# Altium schematic sheet Sheet2.SchDoc
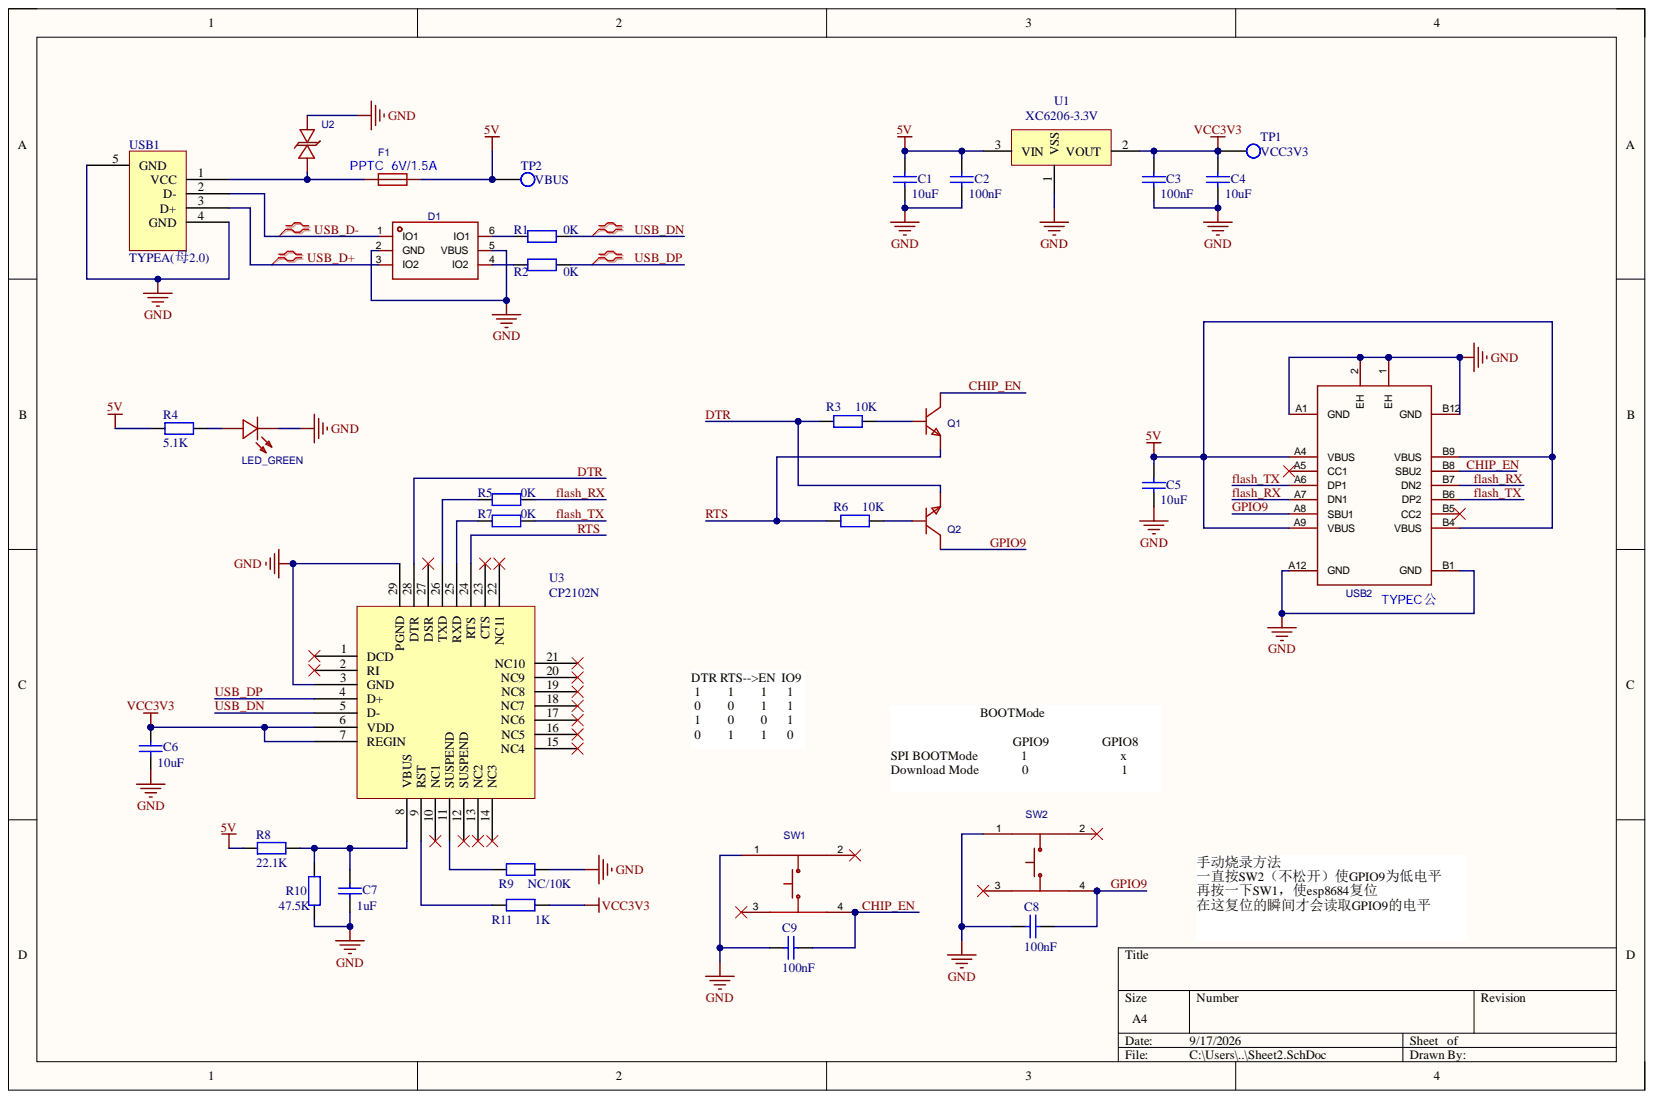
[diagram p0: Sheet2.SchDoc]

## Parts
C1  10uF  [0603_C]
C2  100nF  [0603_C]
C3  100nF  [0603_C]
C4  10uF  [0603_C]
C5  10uF  [0603_C]
C6  10uF  [0603_C]
C7  1uF  [0603_C]
C8  100nF  [0603_C]
C9  100nF  [0603_C]
D1  usblc6-2sc6_c2827654  [SOT-23-6（TVS)]  (value not drawn)
F1  PPTC   6V/1.5A  [0805（PPTC)]
LED_GREEN  ltst-c190kskt  [0805(绿灯）]  (value not drawn)
Q1  l8050qlt1g  [SOT-23(BJT)]  (value not drawn)
Q2  l8050qlt1g  [SOT-23(BJT)]  (value not drawn)
R1  0K  [R0603_L]
R2  0K  [R0603_L]
R3  10K  [R0603_L]
R4  5.1K  [R0603_L]
R5  0K  [R0603_L]
R6  10K  [R0603_L]
R7  0K  [R0603_L]
R8  22.1K  [R0603_L]
R9  NC/10K  [R0603_L]
R10  47.5K  [R0603_L]
R11  1K  [R0603_L]
SW1  ts5215a 250gf  [SMD-4P,5.2x5.2mm(按键）]  (value not drawn)
SW2  ts5215a 250gf  [SMD-4P,5.2x5.2mm(按键）]  (value not drawn)
TP1  VCC3V3  [TEST 1.2]
TP2  VBUS  [TEST 1.2]
U1  XC6206-3.3V  [SOT-23-3L(LDO)]
U2  bnlesd5d5.0ct1g  [SOD-523(TVS)]  (value not drawn)
U3  CP2102N  [QFN-28-EP(5x5)(USB转UART)]
USB1  TYPEA(母2.0)  [TYPEA(母2.0)]
USB2  TYPEC公  Gender: 公  [TYPEC公]

## Nets
5V: C1.2 C2.2 C5.2 F1.2 R4.2 R8.2 TP2.1 U1.3 USB2.A4(VBUS) USB2.A9(VBUS) USB2.B4(VBUS) USB2.B9(VBUS)
GND: C1.1 C2.1 C3.1 C4.1 C5.1 C6.1 C7.1 C8.2 C9.2 D1.2(GND) D1.5(VBUS) LED_GREEN.1 R9.1 R10.2 SW1.1 SW2.1 U1.1 U2.2 U3.3(GND) U3.29(PGND) USB1.4(GND) USB1.5(GND) USB2.1(EH) USB2.2(EH) USB2.A1(GND) USB2.A12(GND) USB2.B1(GND) USB2.B12(GND)
VCC3V3: C3.2 C4.2 C6.2 R11.1 TP1.1 U1.2 U3.6(VDD) U3.7(REGIN)
CHIP_EN: C9.1 Q1.3 SW1.4 USB2.B8(SBU2)
DTR: Q2.2 R3.1 U3.28(DTR)
flash_RX: R5.1 USB2.A7(DN1) USB2.B7(DN2)
flash_TX: R7.1 USB2.A6(DP1) USB2.B6(DP2)
GPIO9: C8.1 Q2.3 SW2.4 USB2.A8(SBU1)
RTS: Q1.2 R6.1 U3.24(RTS)
USB_D+: D1.3(IO2) USB1.3(D+)
USB_D-: D1.1(IO1) USB1.2(D-)
USB_DN: R1.2 U3.5(D-)
USB_DP: R2.2 U3.4(D+)
NetC7_2: C7.2 R8.1 R10.1 U3.8(VBUS)
NetD1_4: D1.4(IO2) R2.1
NetD1_6: D1.6(IO1) R1.1
NetF1_1: F1.1 U2.1 USB1.1(VCC)
NetLED_GREEN_2: LED_GREEN.2 R4.1
NetQ1_1: Q1.1 R3.2
NetQ2_1: Q2.1 R6.2
NetR5_2: R5.2 U3.26(TXD)
NetR7_2: R7.2 U3.25(RXD)
NetR9_2: R9.2 U3.11(SUSPEND)
NetR11_2: R11.2 U3.9(RST)

Not connected: SW1 2 3; SW2 2 3; U3 1(DCD) 2(RI) 10(NC1) 12(SUSPEND) 13(NC2) 14(NC3) 15(NC4) 16(NC5) 17(NC6) 18(NC7) 19(NC8) 20(NC9) 21(NC10) 22(NC11) 23(CTS) 27(DSR); USB2 A5(CC1) B5(CC2)

## Library data of the parts
C1-C9 = 0603 22uF (226) 20% 6.3V: 贴片电容; Component Kind: Standard; ComponentLink1Description: 供应商链接; ComponentLink1URL: http://www.szlcsc.com/product/details_60514.html; Library Name: Miscellaneous Devices LC.IntLib; Package: 0603; Supplier: LC; SuppliersPartNumber: C59461
D1 = usblc6-2sc6_c2827654: 极性:单向;反向截止电压(Vrwm):5V;钳位电压:12V;峰值脉冲电流(Ipp):6A;峰值脉冲功率(Ppp):150W;击穿电压(VBR):6V;; Manufacturer: TECH PUBLIC(台舟); AddInBom: yes; Clamping Voltage: 12V; Junction Capacitance: 0.35pF; level of protection: IEC 61000-4-2；IEC 61000-4-4；IEC 61000-4-5; ModelId: 18da8f6c14a34920b3e136b5c401a119; ModelName: SOT-23-6_L2.9-W1.6-H1.5-LS2.8-P0.95; ModelTransform: 110.236,113.364,0,90,0,0,0.0005,0.0005,0; Number of Channels: 双路; Peak Pulse Current (Ipp): 6A; Peak Pulse Power Dissipation (Ppp): 150W; Polarity: 单向; Reverse Leakage Current (Ir): 80nA; Reverse Stand-Off Voltage (Vrwm): 5V; Type: ESD; Voltage - Breakdown: 6V; Component Kind: Standard; ConvertToPcb: yes; Datasheet: https://item.szlcsc.com/datasheet/USBLC6-2SC6/2973336.html; FootprintName: SOT-23-6_L2.9-W1.6-P0.95-LS2.8-BL; JLCPCB Part Class: Extended Part; LCSC Part Name: 单向ESD 5V截止 峰值脉冲电流：6A; ManufacturerPart: USBLC6-2SC6; Operating Temperature: -55℃~+125℃; Supplier: LCSC; Supplier Footprint: SOT-23-6; SupplierPart: C2827654; Symbol: USBLC6-2SC6_C2827654
F1 = smd0805-150-6: 耐压(Vmax):6V;最大电流(Imax):40A;保持电流(Ihold):1.5A;跳闸电流(Itrip):3A;; Manufacturer: R+O(宏嘉诚); AddInBom: yes; Current - Max: 40A; Hold Current: 1.5A; ModelId: 57e2aa106f9143e8b6ab832aebb31c78; ModelName: F0805_L2.1-W1.4-H0.8; ModelTransform: 82.677,55.118,0,0,0,0,0.0005,0,0; Power Dissipation: 1W; Resistance - Initial (Ri) (Min): 15mΩ; Resistance - Post Trip (R1) (Max): 130mΩ; Time to Trip(Max): 500ms; Trip Current: 3A; Voltage - Max: 6V; Component Kind: Standard; ConvertToPcb: yes; Datasheet: https://item.szlcsc.com/datasheet/SMD0805-150-6/51271788.html; FootprintName: F0805; Height: 1.5mm; JLCPCB Part Class: Extended Part; LCSC Part Name: 6V 1.5A; Length: 2.2mm; ManufacturerPart: SMD0805-150-6; Operating Temperature: -40℃~+85℃; Pitch: -; Supplier: LCSC; Supplier Footprint: 0805; SupplierPart: C48951237; Symbol: SMD0805-150-6; Width: 1.5mm
LED_GREEN = ltst-c190kskt: 绿灯; Manufacturer: LITEON(光宝); AddInBom: yes; Diode Configuration: 独立二极管; Forward Current: 20mA; Illumination Color: 黄色; Lens Color: 无色透明透镜; Luminous Intensity: 104mcd; ModelId: 1f0d29a91be547639d425604e9b96ebb; ModelName: LED0603-RD; ModelTransform: 63.7794,32.283,0,0,0,0,0,0,0; Peak Wavelength: 588nm; Power(Watts): 75mW; Viewing Angle: 130°; Voltage - Forward(Vf): 2.1V; Wavelength - Dominant: 584.5nm~597nm; Color Temperature: -; Component Kind: Standard; ConvertToPcb: yes; Datasheet: https://item.szlcsc.com/datasheet/LTST-C190KSKT/136371.html; FootprintName: LED0603-RD; JLCPCB Part Class: Extended Part; Lamp Holder Type: -; LCSC Part Name: 黄灯; ManufacturerPart: LTST-C190KSKT; Mounting Style: -; Operating Temperature: -30℃~+85℃; Supplier: LCSC; Supplier Footprint: 0603; SupplierPart: C125095; Symbol: LTST-C190KSKT
Q1 Q2 = l8050qlt1g: 晶体管类型:NPN;集电极电流(Ic):800mA;集射极击穿电压(Vceo):25V;耗散功率(Pd):225mW;直流电流增益(hFE):100;; Manufacturer: LRC(乐山无线电); AddInBom: yes; Collector - Emitter Voltage VCEO: 25V; Current - Collector Cutoff: 150nA; Current - Collector(Ic): 800mA; DC Current Gain: 100; Emitter-Base Voltage(Vebo): 5V; ModelId: c62791f0466c4ae2a892c056b845b0ca; ModelName: SOT-23-3P_L2.9-W1.3-H1.0-LS2.4-P0.95; ModelTransform: 98.425,114.173,0,180,0,0,0.0005,-0.0005,0; Number: 1个NPN; Pd - Power Dissipation: 225mW; type: NPN; Vce Saturation(VCE(sat)): 500mV; Component Kind: Standard; ConvertToPcb: yes; Datasheet: https://item.szlcsc.com/datasheet/L8050QLT1G/50589.html; FootprintName: SOT-23-3_L2.9-W1.3-P1.90-LS2.4-BR; JLCPCB Part Class: Extended Part; LCSC Part Name: NPN 电流:800mA 电压:25V; ManufacturerPart: L8050QLT1G; Operating Temperature: -55℃~+150℃; Supplier: LCSC; Supplier Footprint: SOT-23; SupplierPart: C49581; Symbol: L8050QLT1G
R1-R11 = R: 贴片电阻; 联系下单店铺卖家 免费帮你查找封装: 联系下单店铺卖家 免费技术支持; BOM名称: 贴片电阻; Component Kind: Standard; Library Name: 0.1 - 电阻-电容-电感-保险丝-晶振-晶体-磁珠-电位器-法拉电容-馈通电容.SchLib
SW1 SW2 = ts5215a 250gf: 电路结构:单刀单掷;按钮形状:圆形按钮;作用力:2.5N;安装方式:立贴;开关长度:5.2mm;开关宽度:5.2mm;开关高度:1.5mm;带支架:不带支架;触点电流:50mA;额定电压:12V;带灯:无;工作寿命:10万次;; Manufacturer: SHOU HAN(首韩); Actuator Style: 圆形按钮; Actuator/Cap Color: 金色; AddInBom: yes; Circuit: 单刀单掷; Contact Current: 50mA; Life: 10万次; ModelId: d0cf5956b0e74579a1368128ce3f868a; ModelName: KEY-SMD_4P-L5.2-W5.2-H1.5-LS6.4-P3.70; ModelTransform: 251.968,204.724,0,0,0,0,-0.012,0.01,0; Operating Force: 2.5N; Switch Height: 1.5mm; Voltage Rating: 12V; with Bracket: 不带支架; With Lamp: 无; Component Kind: Standard; ConvertToPcb: yes; Datasheet: https://item.szlcsc.com/datasheet/TS5215A%2520250gf/363186.html; FootprintName: SW-SMD_4P-L5.2-W5.2-P3.70-LS6.4; JLCPCB Part Class: Extended Part; LCSC Part Name: 5.2*5.2*1.5mm 立贴 轻触开关; Length: 5.2mm; ManufacturerPart: TS5215A 250gf; Mounting Type: 立贴; Operating Temperature: -25℃~+70℃; Supplier: LCSC; Supplier Footprint: SMD-4P,5.2x5.2mm; SupplierPart: C388295; Symbol: TS5215A 250GF; Termination Style: 鸥翼型; Width: 5.2mm
TP1 TP2 = TP: Component Kind: Standard; Library Name: Schlib1.SchLib
U2 = bnlesd5d5.0ct1g: 极性:双向;反向截止电压(Vrwm):5V;钳位电压:15V;峰值脉冲电流(Ipp):8A@8/20us;峰值脉冲功率(Ppp):120W@8/20us;击穿电压(VBR):9.5V;; Manufacturer: BORN(伯恩半导体); AddInBom: yes; Clamping Voltage: 15V; Junction Capacitance: 18pF; level of protection: IEC 61000-4-4；IEC 61000-4-5；IEC 61000-4-2; ModelId: bfbc20aaa9964d25bc07bd43d1c87046; ModelName: SOD-523_L1.2-W0.8-LS1.6-BI; ModelTransform: 62.992,31.496,0,0,0,0,0,0,0; Number of Channels: 单路; Polarity: 双向; Reverse Leakage Current (Ir): 500nA; Reverse Stand-Off Voltage (Vrwm): 5V; Voltage - Breakdown: 9.5V; Component Kind: Standard; ConvertToPcb: yes; Datasheet: https://item.szlcsc.com/datasheet/BNLESD5D5.0CT1G/21749714.html; FootprintName: SOD-523_L1.2-W0.8-LS1.6-BI; JLCPCB Part Class: Extended Part; LCSC Part Name: 双向ESD 5V截止 峰值浪涌电流：8A@8/20us; ManufacturerPart: BNLESD5D5.0CT1G; Peak Pulse Current (Ipp): 8A@8/20us; Peak Pulse Power Dissipation (Ppp): 120W@8/20us; Supplier: LCSC; Supplier Footprint: SOD-523; SupplierPart: C20416613; Symbol: BNLESD5D5.0CT1G; Type: ESD
USB2 = 24007-ucam001-n: 连接器类型:Type-C;协议标准:-;公母:公;安装方式:夹板;触点数量:16P;端口数量:1;额定电流 - 电源:-;工作温度:-;; Manufacturer: MINTRON(明硕); AddInBom: yes; Connector Type: Type-C; Detection color: 黑色; Number of Contacts: 16P; Number of Ports: 1; Center Height: -; Component Kind: Standard; Connect-Disconnect Life: -; ConvertToPcb: yes; Current Rating-Power: -; Datasheet: https://item.szlcsc.com/datasheet/24007-UCAM001-N/3708465.html; FootprintName: USB-SMD_24007-UCAM001-N; JLCPCB Part Class: Extended Part; LCSC Part Name: Type-C 公 夹板; Length: 9.75mm; ManufacturerPart: 24007-UCAM001-N; Mounting Type: 夹板; Operating Temperature: -; Protocol Standard: -; Supplementary Features: -; Supplier: LCSC; Supplier Footprint: SMD; SupplierPart: C3148224; Symbol: 24007-UCAM001-N; Voltage Rating: -
U1 = XC6206-3.3V: Component Kind: Standard; Library Name: Schlib1.SchLib
U3 = CP2102N: Component Kind: Standard; Library Name: Schlib1.SchLib
USB1 = TYPEA(母2.0): Component Kind: Standard; Library Name: Schlib1.SchLib
